# Altium schematic sheet BP6A_EVK_2.SchDoc(BP6A_EVK_2)
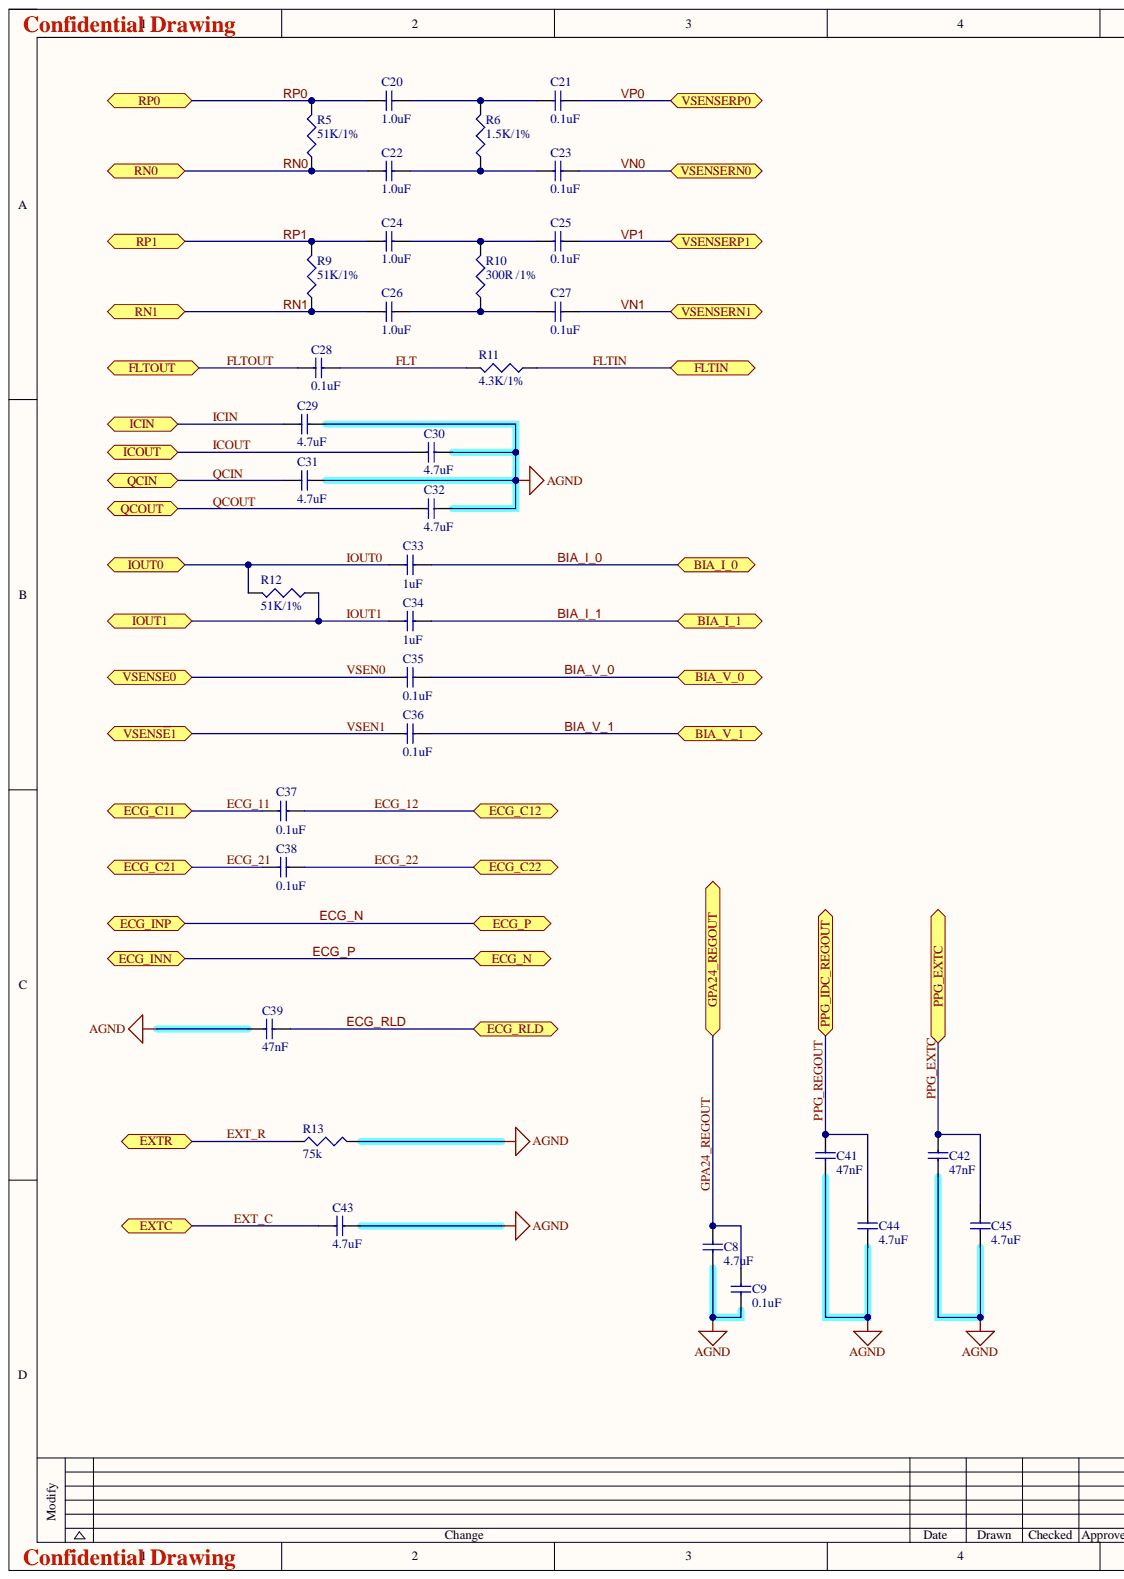
[diagram p0: BP6A_EVK_2.SchDoc, left]
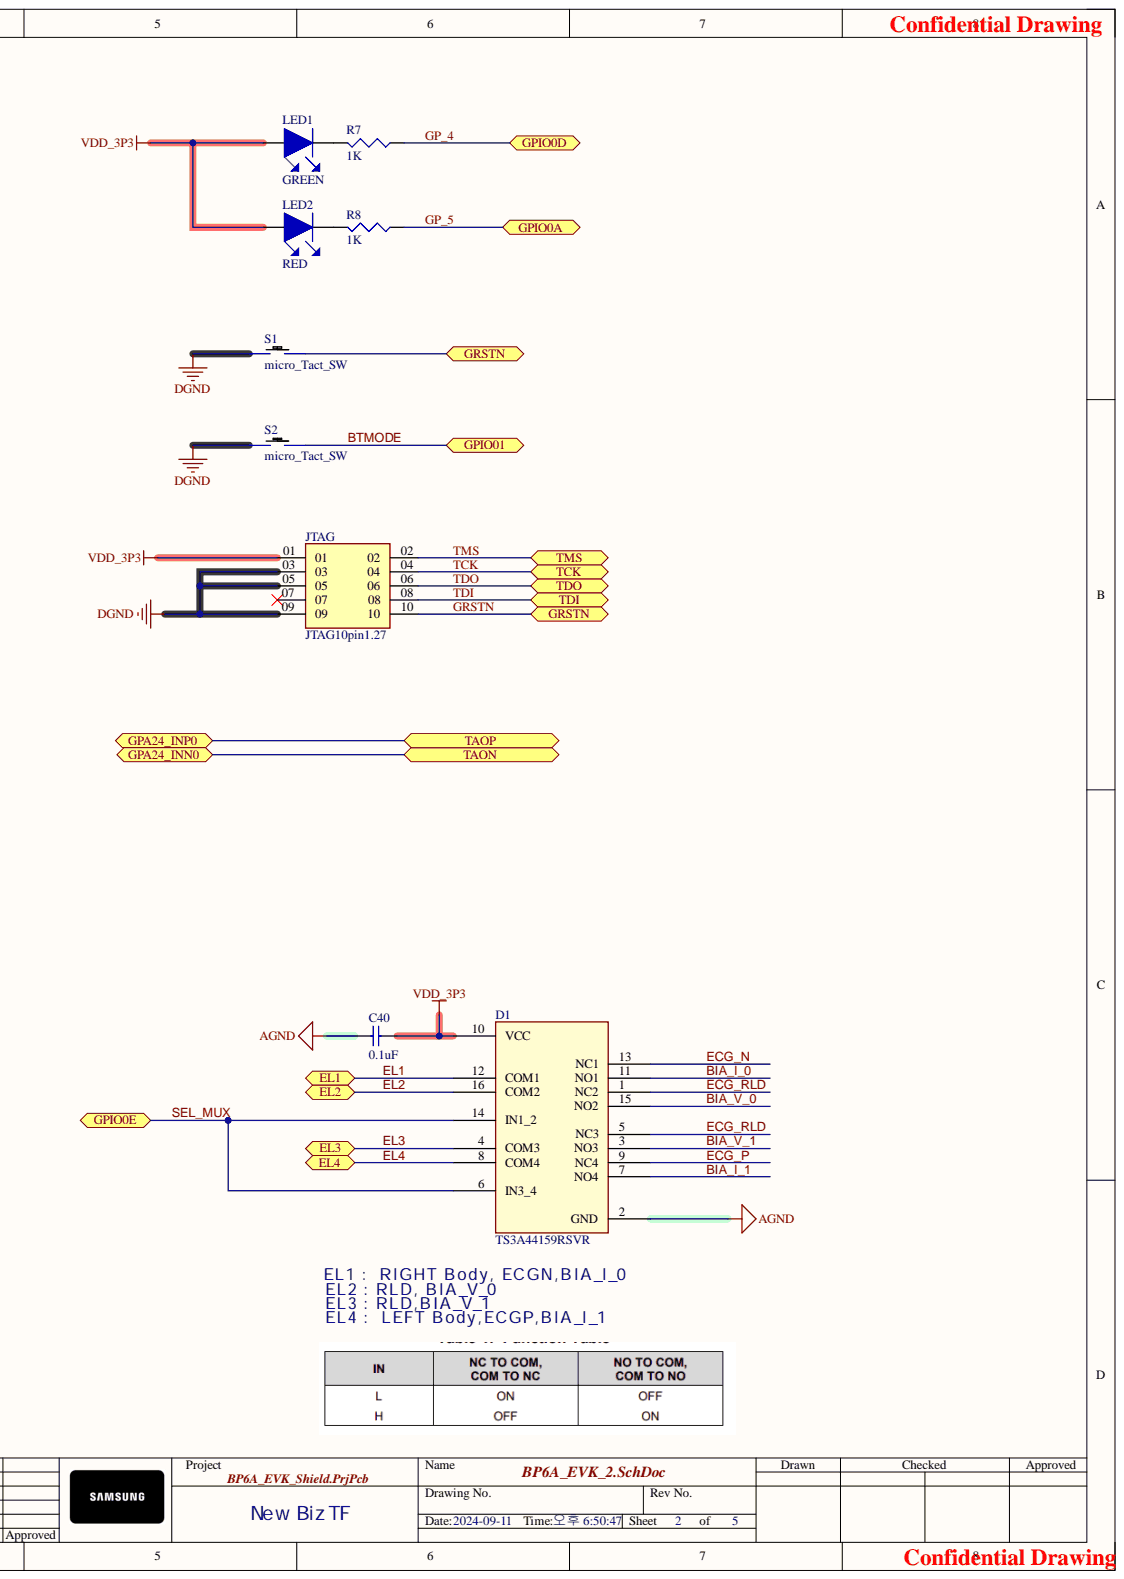
[diagram p1: BP6A_EVK_2.SchDoc, right]

## Parts
C8  4.7uF  [MLCC_1005]
C9  0.1uF  [MLCC_1005]
C20  1.0uF  [MLCC_1005]
C21  0.1uF  [MLCC_1005]
C22  1.0uF  [MLCC_1005]
C23  0.1uF  [MLCC_1005]
C24  1.0uF  [MLCC_1005]
C25  0.1uF  [MLCC_1005]
C26  1.0uF  [MLCC_1005]
C27  0.1uF  [MLCC_1005]
C28  0.1uF  [MLCC_1005]
C29  4.7uF  [MLCC_1005]
C30  4.7uF  [MLCC_1005]
C31  4.7uF  [MLCC_1005]
C32  4.7uF  [MLCC_1005]
C33  1uF  [MLCC_1005]
C34  1uF  [MLCC_1005]
C35  0.1uF  [MLCC_1005]
C36  0.1uF  [MLCC_1005]
C37  0.1uF  [MLCC_1005]
C38  0.1uF  [MLCC_1005]
C39  47nF  [MLCC_1005]
C40  0.1uF  [MLCC_1005]
C41  47nF  [MLCC_1005]
C42  47nF  [MLCC_1005]
C43  4.7uF  [MLCC_1005]
C44  4.7uF  [MLCC_1005]
C45  4.7uF  [MLCC_1005]
D1  TS3A44159RSVR  [TS3A44159RSVR]
JTAG  JTAG10pin1.27  [JTAG10pin1.27]
LED1  GREEN  [LED_1608]
LED2  RED  [LED_1608]
R5  51K/1%  [RES_1005]
R6  1.5K/1%  [RES_1005]
R7  1K  [RES_1005]
R8  1K  [RES_1005]
R9  51K/1%  [RES_1005]
R10  300R /1%  [RES_1005]
R11  4.3K/1%  [RES_1005]
R12  51K/1%  [RES_1005]
R13  75k  [RES_1005]
S1  micro_Tact_SW  [micro_Tact_SW]
S2  micro_Tact_SW  [micro_Tact_SW]

## Ports
BIA_I_0, BIA_I_1, BIA_V_0, BIA_V_1, ECG_C11, ECG_C12, ECG_C21, ECG_C22, ECG_INN, ECG_INP, ECG_N, ECG_P, ECG_RLD, EL1, EL2, EL3, EL4, EXTC, EXTR, FLTIN, FLTOUT, GPA24_INN0, GPA24_INP0, GPA24_REGOUT, GPIO0A, GPIO0D, GPIO0E, GPIO01, GRSTN, ICIN, ICOUT, IOUT0, IOUT1, PPG_EXTC, PPG_IDC_REGOUT, QCIN, QCOUT, RN0, RN1, RP0, RP1, TAON, TAOP, TCK, TDI, TDO, TMS, VSENSE0, VSENSERN0, VSENSERN1, VSENSERP0, VSENSERP1, VSENS~{E}1

## Nets
AGND: C8.1 C9.1 C29.1 C30.1 C31.1 C32.1 C39.1 C40.1 C41.1 C42.1 C43.2 C44.1 C45.1 D1.2(GND) R13.2
DGND: JTAG.03 JTAG.05 JTAG.09 S1.1 S2.1
VDD_3P3: C40.2 D1.10(VCC) JTAG.01 LED1.1 LED2.1
BIA_I_0: C33.2 D1.11(NO1) ; port BIA_I_0
BIA_I_1: C34.2 D1.7(NO4) ; port BIA_I_1
BIA_V_0: C35.2 D1.15(NO2) ; port BIA_V_0
BIA_V_1: C36.2 D1.3(NO3) ; port BIA_V_1
BTMODE: S2.2 ; port GPIO01
ECG_11: C37.1 ; port ECG_C11
ECG_12: C37.2 ; port ECG_C12
ECG_21: C38.1 ; port ECG_C21
ECG_22: C38.2 ; port ECG_C22
ECG_N: D1.13(NC1) ; port ECG_INP, port ECG_P
ECG_P: D1.9(NC4) ; port ECG_INN, port ECG_N
ECG_RLD: C39.2 D1.1(NC2) D1.5(NC3) ; port ECG_RLD
EL1: D1.12(COM1) ; port EL1
EL2: D1.16(COM2) ; port EL2
EL3: D1.4(COM3) ; port EL3
EL4: D1.8(COM4) ; port EL4
EXT_C: C43.1 ; port EXTC
EXT_R: R13.1 ; port EXTR
FLT: C28.2 R11.2
FLTIN: R11.1 ; port FLTIN
FLTOUT: C28.1 ; port FLTOUT
GP_4: R7.1 ; port GPIO0D
GP_5: R8.1 ; port GPIO0A
GPA24_REGOUT: C8.2 C9.2 ; port GPA24_REGOUT
GRSTN: JTAG.10 S1.2 ; port GRSTN
ICIN: C29.2 ; port ICIN
ICOUT: C30.2 ; port ICOUT
IOUT0: C33.1 R12.2 ; port IOUT0
IOUT1: C34.1 R12.1 ; port IOUT1
PPG_EXTC: C42.2 C45.2 ; port PPG_EXTC
PPG_REGOUT: C41.2 C44.2 ; port PPG_IDC_REGOUT
QCIN: C31.2 ; port QCIN
QCOUT: C32.2 ; port QCOUT
RN0: C22.1 R5.1 ; port RN0
RN1: C26.1 R9.1 ; port RN1
RP0: C20.1 R5.2 ; port RP0
RP1: C24.1 R9.2 ; port RP1
SEL_MUX: D1.6(IN3_4) D1.14(IN1_2) ; port GPIO0E
TCK: JTAG.04 ; port TCK
TDI: JTAG.08 ; port TDI
TDO: JTAG.06 ; port TDO
TMS: JTAG.02 ; port TMS
VN0: C23.2 ; port VSENSERN0
VN1: C27.2 ; port VSENSERN1
VP0: C21.2 ; port VSENSERP0
VP1: C25.2 ; port VSENSERP1
VSEN0: C35.1 ; port VSENSE0
VSEN1: C36.1 ; port VSENS~{E}1
NetC20_2: C20.2 C21.1 R6.2
NetC22_2: C22.2 C23.1 R6.1
NetC24_2: C24.2 C25.1 R10.2
NetC26_2: C26.2 C27.1 R10.1
NetLED1_2: LED1.2 R7.2
NetLED2_2: LED2.2 R8.2
N00001: ; port GPA24_INP0, port TAOP
N00002: ; port GPA24_INN0, port TAON

Not connected: JTAG 07

## Library data of the parts
C8 C9 C40-C42 C44 C45 = MLCC: Component Kind: Standard; Description: MLCC; Library Name: my_components.IntLib
C20-C39 C43 = MLCC_1: 0603MLCC, ±10%; Part Number: C0603-4µ7; Artikkelnr Noca: 20069060; Artikkelnr Simpro: N.A.; Note: 6.3V; Value: 4.7µF; Component Kind: Standard; Footprint Doc: 0603; LatestRevisionDate: 2009-11-23; Pin Count: 2; Published: 2009-05-08; Library Name: pebble.SCHLIB; Publisher: Nordic Semiconductor
D1 = TS3A44159RSVR: BODY SIZE: 2.6mmX1.8mm; Vender: TEXAS INSTRUMENTS; Component Kind: Standard; FEATURE: 0.45R/4CH 2:1 MUX; Library Name: my_component.SchLib; PACKAGE: UQFN(16); PART NUMBER: TS3A44159
JTAG = JTAG10pin1.27: Conn Unshrouded Header HDR 10 POS 1.27mm Solder ST Thru-Hole Bulk; MF: Samtec; MP: MTMS-105-02-G-D-100; Availability: Warning; Check_prices: https://www.snapeda.com/parts/MTMS-105-02-G-D-100/Samtec/view-part/3541868/?ref=eda; Component Kind: Standard; Library Name: my_components.IntLib; Package: None; Price: None; Purchase-URL: https://pricing.snapeda.com/search/part/MTMS-105-02-G-D-100/?ref=eda; SnapEDA_Link: https://www.snapeda.com/parts/MTMS-105-02-G-D-100/Samtec/view-part/3541868/?ref=snap
LED1 LED2 = LED_1005: 1005 LED; Component Kind: Standard; Library Name: my_components.IntLib
R5-R13 = Res: 0603Chip Resistor; Value: 10K; Component Kind: Standard; LatestRevisionDate: 29-May-2009; LatestRevisionNote: IPC-7351 Footprint Added.; PackageDescription: Chip Resistor; PackageReference: J1-0603; Published: 8-Jun-2000; Signal Integrity: Res; Simulation: RESISTOR; Library Name: pebble.SCHLIB; Publisher: Altium Limited
S1 S2 = micro_Tact_SW: NW3-A06-B3; Component Kind: Standard; Library Name: my_component.SchLib
